# Altium schematic sheet Rat Tracker Top Sheet.SchDoc
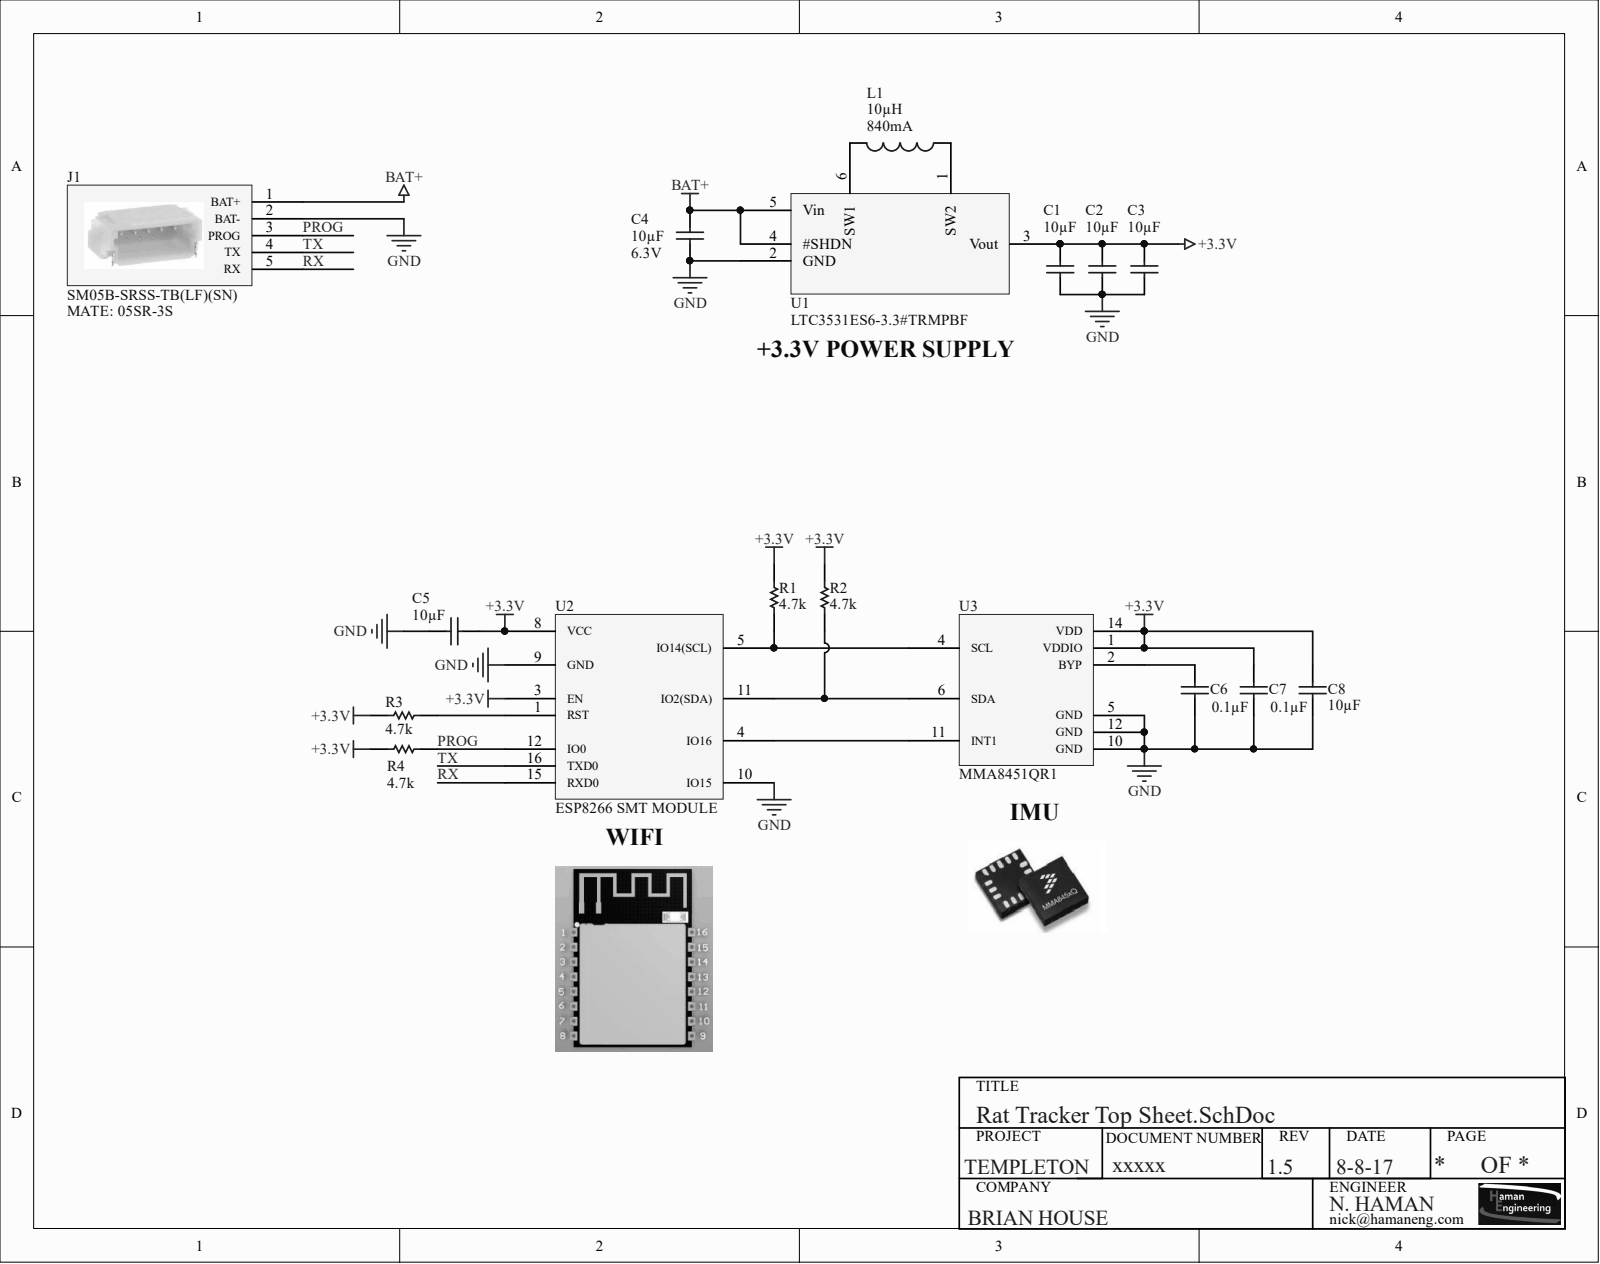
[diagram p0: Rat Tracker Top Sheet.SchDoc]

## Parts
C1  Capacitance: 10µF  [0603-C]  (hidden comment: 50V)
C2  Capacitance: 10µF  [0603-C]  (hidden comment: 50V)
C3  Capacitance: 10µF  [0603-C]  (hidden comment: 50V)
C4  Capacitance: 10µF  Voltage - Rated: 6.3V  [0603-C]  (hidden comment: 50V)
C5  Capacitance: 10µF  [0603-C]  (hidden comment: 50V)
C6  Capacitance: 0.1µF  [0603-C]  (hidden comment: 50V)
C7  Capacitance: 0.1µF  [0603-C]  (hidden comment: 50V)
C8  Capacitance: 10µF  [0603-C]  (hidden comment: 50V)
J1  SM05B-SRSS-TB(LF)(SN)  MATE: 05SR-3S  [SM05B-SRSS-TB(LF)(SN)]
L1  Current - Saturation: 840mA  Inductance: 10µH  [CDRH3D16/HPNP-100MC]  (hidden comment: Inductor)
R1  Resistance (Ohms): 4.7k  [0603-R]  (hidden comment: Res1)
R2  Resistance (Ohms): 4.7k  [0603-R]  (hidden comment: Res1)
R3  Resistance (Ohms): 4.7k  [0603-R]  (hidden comment: Res1)
R4  Resistance (Ohms): 4.7k  [0603-R]  (hidden comment: Res1)
U1  LTC3531ES6-3.3#TRMPBF  [SOT-23-6]
U2  ESP8266  Description1: ESP8266 SMT MODULE  [ESP8266]
U3  MMA8451QR1  [VFQFN-16]

## Nets
+3.3V: C1.2 C2.2 C3.2 C5.1 C7.1 C8.1 R1.2 R2.2 R3.2 R4.2 U1.3(Vout) U2.3(EN) U2.8(VCC) U3.1(VDDIO) U3.14(VDD)
BAT+: C4.2 J1.1(BAT+) U1.4(#SHDN) U1.5(Vin)
GND: C1.1 C2.1 C3.1 C4.1 C5.2 C6.2 C7.2 C8.2 J1.2(BAT-) U1.2(GND) U2.9(GND) U2.10(IO15) U3.5(GND) U3.10(GND) U3.12(GND)
PROG: J1.3(PROG) R4.1 U2.12(IO0)
RX: J1.5(RX) U2.15(RXD0)
TX: J1.4(TX) U2.16(TXD0)
NetC6_1: C6.1 U3.2(BYP)
NetL1_1: L1.1 U1.1(SW2)
NetL1_2: L1.2 U1.6(SW1)
NetR1_1: R1.1 U2.5(IO14(SCL)) U3.4(SCL)
NetR2_1: R2.1 U2.11(IO2(SDA)) U3.6(SDA)
NetR3_1: R3.1 U2.1(RST)
NetU2_4: U2.4(IO16) U3.11(INT1)

## Library data of the parts
C1-C3 C5 C8 = Cap: CAP .1UF 50V CERAMIC Y5V 0805; Manufacturer: Taiyo Yuden; Manufacturer Part Number: JMK107BJ106MA-T; Description1: CAP CER 10UF 6.3V X5R 0603; Temperature Coefficient: X5R; Tolerance: ±20%; Voltage - Rated: 6.3V; Applications: General Purpose; Category: Capacitors; Component Kind: Standard; ComponentLink1Description: http://www.yuden.co.jp/productdata/catalog/en/mlcc_all_e.pdf; ComponentLink1URL: http://www.yuden.co.jp/productdata/catalog/en/mlcc_all_e.pdf; Features: -; Height - Seated (Max): -; Lead Spacing: -; Lead Style: -; Library Name: Miscellaneous Devices.IntLib; Mounting Type: Surface Mount, MLCC; Operating Temperature: -55°C ~ 85°C; Package / Case: 0603 (1608 Metric); Packaging: Cut Tape (CT); Part Status: Active; Pricing 1: 1=0.16, 10=0.111, 100=0.0523, 500=0.03668, 1000=0.03036 (USD); Ratings: -; Revision: July-2002: Re-released for DXP Platform.; RoHS: RoHS Compliant; Size / Dimension: 0.063" L x 0.031" W (1.60mm x 0.80mm); Stock 1: 605215; Supplier 1: Digi-Key; Supplier Part Number 1: 587-1256-1-ND; Thickness (Max): 0.035" (0.90mm)
C4 = Cap: CAP .1UF 50V CERAMIC Y5V 0805; Manufacturer: Taiyo Yuden; Manufacturer Part Number: JMK107BJ106MA-T; Description1: CAP CER 10UF 6.3V X5R 0603; Temperature Coefficient: X5R; Tolerance: ±20%; Applications: General Purpose; Category: Capacitors; Component Kind: Standard; ComponentLink1Description: http://www.yuden.co.jp/productdata/catalog/en/mlcc_all_e.pdf; ComponentLink1URL: http://www.yuden.co.jp/productdata/catalog/en/mlcc_all_e.pdf; Features: -; Height - Seated (Max): -; Lead Spacing: -; Lead Style: -; Library Name: Miscellaneous Devices.IntLib; Mounting Type: Surface Mount, MLCC; Operating Temperature: -55°C ~ 85°C; Package / Case: 0603 (1608 Metric); Packaging: Cut Tape (CT); Part Status: Active; Pricing 1: 1=0.16, 10=0.111, 100=0.0523, 500=0.03668, 1000=0.03036 (USD); Ratings: -; Revision: July-2002: Re-released for DXP Platform.; RoHS: RoHS Compliant; Size / Dimension: 0.063" L x 0.031" W (1.60mm x 0.80mm); Stock 1: 605215; Supplier 1: Digi-Key; Supplier Part Number 1: 587-1256-1-ND; Thickness (Max): 0.035" (0.90mm)
C6 C7 = Cap: CAP .1UF 50V CERAMIC Y5V 0805; Manufacturer: Murata Electronics North America; Manufacturer Part Number: GRM188R71H104KA93D; Description1: CAP CER 0.1UF 50V X7R 0603; Temperature Coefficient: X7R; Tolerance: ±10%; Applications: General Purpose; Category: Capacitors; Component Kind: Standard; ComponentLink1Description: http://www.murata.com/~/media/webrenewal/support/library/catalog/products/capacitor/mlcc/c02e.ashx; ComponentLink1URL: http://www.murata.com/~/media/webrenewal/support/library/catalog/products/capacitor/mlcc/c02e.ashx; Features: -; Height - Seated (Max): -; Lead Spacing: -; Lead Style: -; Library Name: Miscellaneous Devices.IntLib; Mounting Type: Surface Mount, MLCC; Operating Temperature: -55°C ~ 125°C; Package / Case: 0603 (1608 Metric); Packaging: Cut Tape (CT); Part Status: Active; Pricing 1: 1=0.1, 10=0.046, 100=0.0205, 500=0.01464, 1000=0.0115 (USD); Ratings: -; Revision: July-2002: Re-released for DXP Platform.; RoHS: RoHS Compliant; Size / Dimension: 0.063" L x 0.031" W (1.60mm x 0.80mm); Stock 1: 13311662; Supplier 1: Digi-Key; Supplier Part Number 1: 490-1519-1-ND; Thickness (Max): 0.035" (0.90mm); Voltage - Rated: 50V
J1 = SM05B-SRSS-TB(LF)(SN): Manufacturer: JST Sales America Inc.; Connector Type: Header; Current Rating: 0.7A; Description1: CONN HEADER SH 5POS SIDE 1MM TIN; Mated Stacking Heights: 2.95mm; Material Flammability Rating: UL94 V-0; Number of Positions: 5; Number of Positions Loaded: All; Pitch - Mating: 0.039" (1.00mm); Shrouding: Shrouded - 4 Wall; Style: Board to Cable/Wire; Voltage Rating: 50V; Applications: -; Category: Connectors, Interconnects; Component Kind: Standard; ComponentLink1Description: http://www.jst-mfg.com/product/pdf/eng/eSH.pdf; ComponentLink1URL: http://www.jst-mfg.com/product/pdf/eng/eSH.pdf; Contact Finish - Mating: Tin; Contact Finish - Post: Tin; Contact Finish Thickness - Mating: -; Contact Finish Thickness - Post: -; Contact Length - Mating: -; Contact Length - Post: -; Contact Material: Copper Alloy; Contact Shape: Square; Contact Type: Male Pin; Fastening Type: -; Features: Solder Retention; Ingress Protection: -; Insulation Color: Natural; Insulation Height: 0.114" (2.90mm); Insulation Material: Polyamide (PA), Nylon; Library Name: Schlib1.SchLib; Manufacturer Part Number: SM05B-SRSS-TB(LF)(SN); Mounting Type: Surface Mount, Right Angle; Number of Rows: 1; Operating Temperature: -25°C ~ 85°C; Overall Contact Length: -; Packaging: Cut Tape (CT); Part Status: Active; Pricing 1: 1=0.75, 10=0.706, 100=0.5412, 500=0.47058, 1000=0.38824 (USD); RoHS: RoHS Compliant; Row Spacing - Mating: -; Stock 1: 247482; Supplier 1: Digi-Key; Supplier Part Number 1: 455-1805-1-ND; Termination: Solder
L1 = Inductor: Manufacturer: Sumida America Components Inc.; Current Rating: 1A; DC Resistance (DCR): 230 mOhm Max; Description1: FIXED IND 10UH 1A 230 MOHM SMD; Material - Core: Ferrite; Shielding: Shielded; Tolerance: ±20%; Category: Inductors, Coils, Chokes; Component Kind: Standard; ComponentLink1Description: http://products.sumida.com/products/pdf/CDRH3D16HP.pdf; ComponentLink1URL: http://products.sumida.com/products/pdf/CDRH3D16HP.pdf; Description: Inductor; Frequency - Self Resonant: -; Height - Seated (Max): 0.071" (1.80mm); Library Name: Miscellaneous Devices.IntLib; Manufacturer Part Number: CDRH3D16/HPNP-100MC; Mounting Type: Surface Mount; Operating Temperature: -40°C ~ 105°C; Package / Case: Nonstandard; Packaging: Cut Tape (CT); Part Status: Active; Pricing 1: 1=0.97, 10=0.845, 100=0.6038, 500=0.50716 (USD); Q @ Freq: -; Ratings: -; RoHS: RoHS Compliant; Size / Dimension: 0.150" L x 0.150" W (3.80mm x 3.80mm); Stock 1: 2515; Supplier 1: Digi-Key; Supplier Device Package: -; Supplier Part Number 1: 308-1977-1-ND; Type: -
R1-R4 = Res1: Resistor; Manufacturer: Yageo; Manufacturer Part Number: RC0603FR-074K7L; Description1: RES SMD 4.7K OHM 1% 1/10W 0603; Power (Watts): 0.1W, 1/10W; Temperature Coefficient: ±100ppm/°C; Tolerance: ±1%; Category: Resistors; Component Kind: Standard; ComponentLink1Description: http://www.yageo.com/documents/recent/PYu-RC_Group_51_RoHS_L_7.pdf; ComponentLink1URL: http://www.yageo.com/documents/recent/PYu-RC_Group_51_RoHS_L_7.pdf; Composition: Thick Film; Failure Rate: -; Features: Moisture Resistant; Height - Seated (Max): 0.022" (0.55mm); Library Name: Miscellaneous Devices.IntLib; Operating Temperature: -55°C ~ 155°C; Package / Case: 0603 (1608 Metric); Packaging: Digi-Reel®; Part Status: Active; Pricing 1: 1=0.1, 10=0.015, 100=0.006, 1000=0.00268, 2500=0.00233 (USD); RoHS: RoHS Compliant; Size / Dimension: 0.063" L x 0.031" W (1.60mm x 0.80mm); Stock 1: 5862967; Supplier 1: Digi-Key; Supplier Device Package: 0603; Supplier Part Number 1: 311-4.70KHRDKR-ND
U1 = LTC3531ES6-3.3#TRMPBF: Manufacturer: Linear Technology; Current - Output: 200mA; Description1: IC REG BCK BST 3.32V 0.2A TSOT23; Function: Step-Up/Step-Down; Number of Outputs: 1; Output Configuration: Positive; Output Type: Fixed; Synchronous Rectifier: Yes; Topology: Buck-Boost; Voltage - Input (Max): 5.5V; Voltage - Input (Min): 1.8V; Voltage - Output (Min/Fixed): 3.32V; Category: Integrated Circuits (ICs); Component Kind: Standard; ComponentLink1Description: http://www.linear.com/docs/11037; ComponentLink1URL: http://www.linear.com/docs/11037; Frequency - Switching: -; Manufacturer Part Number: LTC3531ES6-3.3#TRMPBF; Mounting Type: Surface Mount; Operating Temperature: -40°C ~ 85°C (TA); Package / Case: SOT-23-6 Thin, TSOT-23-6; Packaging: Tape & Reel (TR); Pricing 1: 500=2.35 (USD); RoHS: RoHS Compliant; Stock 1: 4000; Supplier 1: Digi-Key; Supplier Device Package: TSOT-23-6; Supplier Part Number 1: LTC3531ES6-3.3#TRMPBFTR-ND; Voltage - Output (Max): -; Library Name: QF BT SCHLIB.SchLib
U2 = ESP8266: Manufacturer: Adafruit Industries LLC; Manufacturer Part Number: 2491; Antenna Type: Integrated, Trace; Current - Receiving: 50mA ~ 56mA; Current - Transmitting: 120mA ~ 170mA; Data Rate: 72.2Mbps; Frequency: 2.4GHz; Power - Output: 20.5dBm; Protocol: 802.11b/g/n; RF Family/Standard: WiFi; Sensitivity: -98dBm; Serial Interfaces: I²C, I²S, SPI, UART; Voltage - Supply: 3 V ~ 3.6 V; Category: RF/IF and RFID; Component Kind: Standard; ComponentLink1Description: https://www.adafruit.com/images/product-files/2471/0A-ESP8266__Datasheet__EN_v4.3.pdf; ComponentLink1URL: https://www.adafruit.com/images/product-files/2471/0A-ESP8266__Datasheet__EN_v4.3.pdf; Library Name: Schlib1.SchLib; Memory Size: -; Modulation: -; Mounting Type: Surface Mount; Operating Temperature: -40°C ~ 125°C; Package / Case: Module; Packaging: Bulk; Part Status: Active; Pricing 1: 1=6.49 (USD); RoHS: RoHS Compliant; Stock 1: 171; Supplier 1: Digi-Key; Supplier Part Number 1: 1528-1438-ND
U3 = MMA8451QR1: Manufacturer: NXP USA Inc.; Acceleration Range: ±2g, 4g, 8g; Axis: X, Y, Z; Bandwidth: 0.78Hz ~ 400Hz; Description1: ACCELEROMETER 2-8G I2C 16QFN; Output Type: I²C; Sensitivity (LSB/g): 4096 (±2g) ~ 1024 (±8g); Voltage - Supply: 1.95 V ~ 3.6 V; Category: Sensors, Transducers; Component Kind: Standard; ComponentLink1Description: http://cache.freescale.com/files/sensors/doc/data_sheet/MMA8451Q.pdf; ComponentLink1URL: http://cache.freescale.com/files/sensors/doc/data_sheet/MMA8451Q.pdf; Features: Adjustable Bandwidth, Selectable Scale, Sleep Mode; Library Name: Schlib1.SchLib; Manufacturer Part Number: MMA8451QR1; Mounting Type: Surface Mount; Operating Temperature: -40°C ~ 85°C (TA); Package / Case: 16-VFQFN; Packaging: Cut Tape (CT); Part Status: Active; Pricing 1: 1=2.65, 5=2.502, 10=2.145, 25=1.716, 50=1.573, 100=1.43, 500=1.25126 (USD); RoHS: RoHS Compliant; Sensitivity (mV/g): -; Stock 1: 59633; Supplier 1: Digi-Key; Supplier Device Package: 16-QFN (3x3); Supplier Part Number 1: MMA8451QR1CT-ND; Type: Digital
